# Altium schematic sheet 04 DI.SchDoc
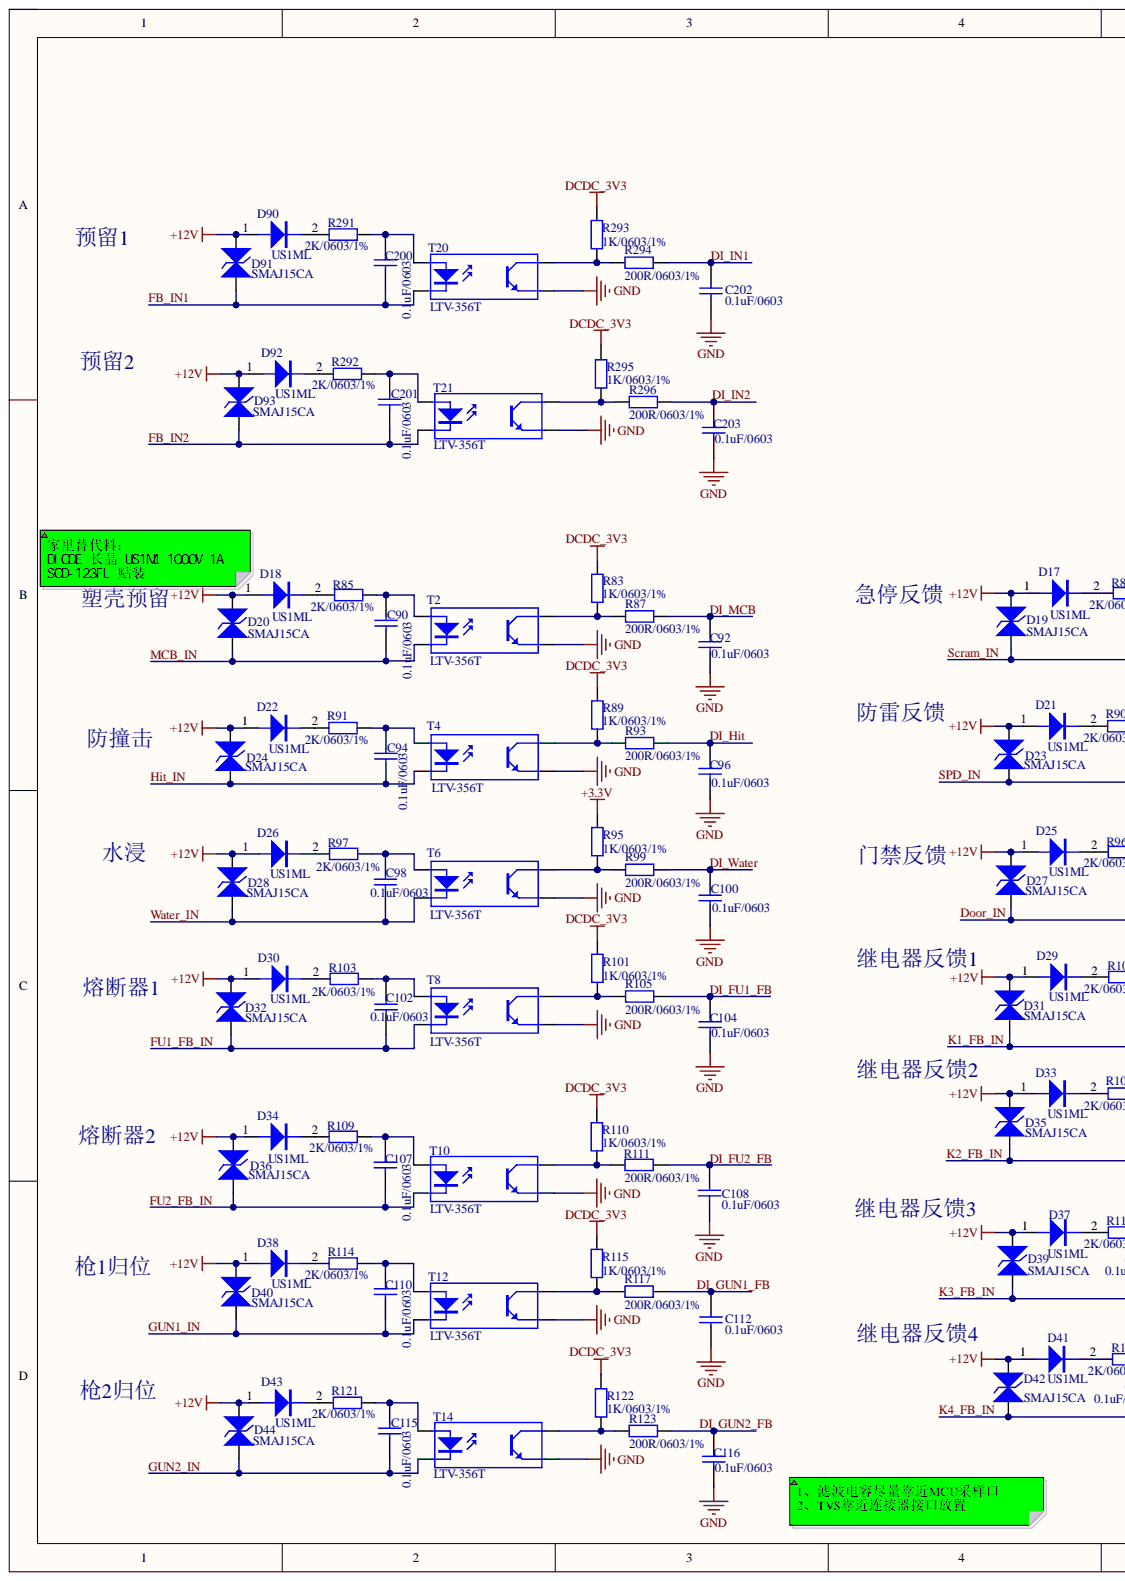
[diagram p0: 04 DI.SchDoc, left]
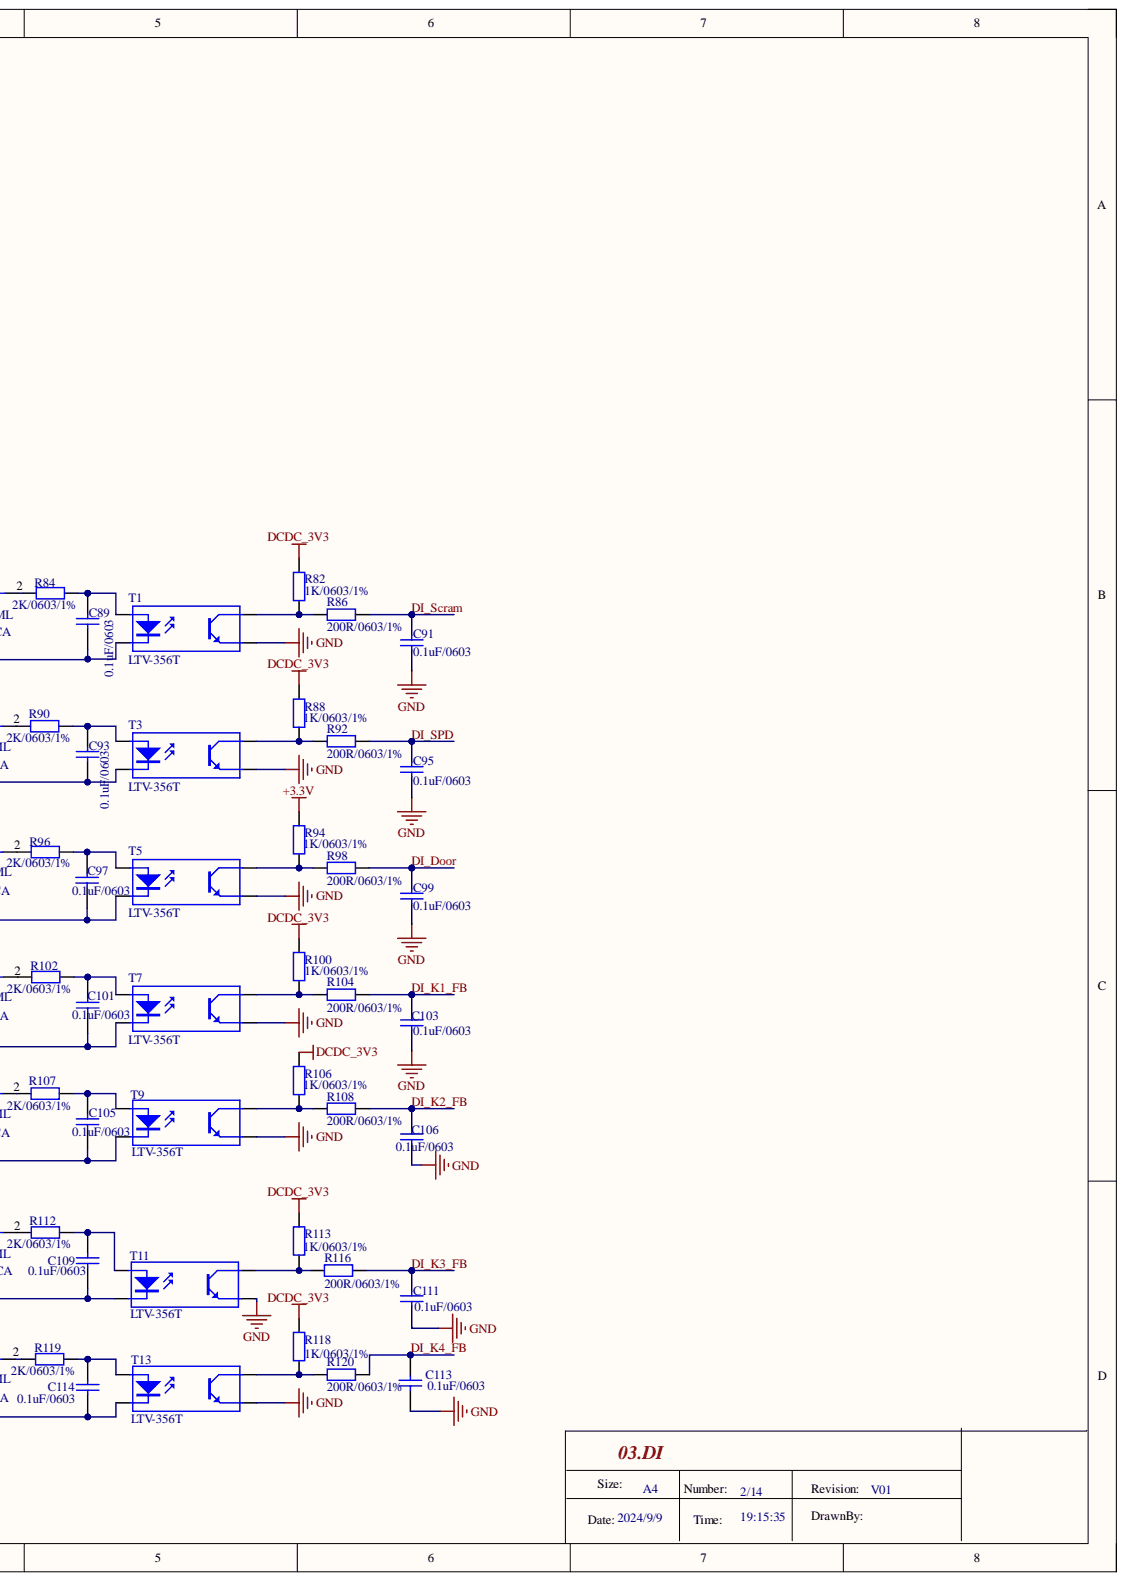
[diagram p1: 04 DI.SchDoc, right]

## Parts
C89  0.1uF/0603  [C0603]
C90  0.1uF/0603  [C0603]
C91  0.1uF/0603  [C0603]
C92  0.1uF/0603  [C0603]
C93  0.1uF/0603  [C0603]
C94  0.1uF/0603  [C0603]
C95  0.1uF/0603  [C0603]
C96  0.1uF/0603  [C0603]
C97  0.1uF/0603  [C0603]
C98  0.1uF/0603  [C0603]
C99  0.1uF/0603  [C0603]
C100  0.1uF/0603  [C0603]
C101  0.1uF/0603  [C0603]
C102  0.1uF/0603  [C0603]
C103  0.1uF/0603  [C0603]
C104  0.1uF/0603  [C0603]
C105  0.1uF/0603  [C0603]
C106  0.1uF/0603  [C0603]
C107  0.1uF/0603  [C0603]
C108  0.1uF/0603  [C0603]
C109  0.1uF/0603  [C0603]
C110  0.1uF/0603  [C0603]
C111  0.1uF/0603  [C0603]
C112  0.1uF/0603  [C0603]
C113  0.1uF/0603  [C0603]
C114  0.1uF/0603  [C0603]
C115  0.1uF/0603  [C0603]
C116  0.1uF/0603  [C0603]
C200  0.1uF/0603  [C0603]
C201  0.1uF/0603  [C0603]
C202  0.1uF/0603  [C0603]
C203  0.1uF/0603  [C0603]
D17  US1ML  [SOD123]
D18  US1ML  [SOD123]
D19  SMAJ15CA  [SMA]
D20  SMAJ15CA  [SMA]
D21  US1ML  [SOD123]
D22  US1ML  [SOD123]
D23  SMAJ15CA  [SMA]
D24  SMAJ15CA  [SMA]
D25  US1ML  [SOD123]
D26  US1ML  [SOD123]
D27  SMAJ15CA  [SMA]
D28  SMAJ15CA  [SMA]
D29  US1ML  [SOD123]
D30  US1ML  [SOD123]
D31  SMAJ15CA  [SMA]
D32  SMAJ15CA  [SMA]
D33  US1ML  [SOD123]
D34  US1ML  [SOD123]
D35  SMAJ15CA  [SMA]
D36  SMAJ15CA  [SMA]
D37  US1ML  [SOD123]
D38  US1ML  [SOD123]
D39  SMAJ15CA  [SMA]
D40  SMAJ15CA  [SMA]
D41  US1ML  [SOD123]
D42  SMAJ15CA  [SMA]
D43  US1ML  [SOD123]
D44  SMAJ15CA  [SMA]
D90  US1ML  [SOD123]
D91  SMAJ15CA  [SMA]
D92  US1ML  [SOD123]
D93  SMAJ15CA  [SMA]
R82  1K/0603/1%  [R0603]
R83  1K/0603/1%  [R0603]
R84  2K/0603/1%  [R0603]
R85  2K/0603/1%  [R0603]
R86  200R/0603/1%  [R0603]
R87  200R/0603/1%  [R0603]
R88  1K/0603/1%  [R0603]
R89  1K/0603/1%  [R0603]
R90  2K/0603/1%  [R0603]
R91  2K/0603/1%  [R0603]
R92  200R/0603/1%  [R0603]
R93  200R/0603/1%  [R0603]
R94  1K/0603/1%  [R0603]
R95  1K/0603/1%  [R0603]
R96  2K/0603/1%  [R0603]
R97  2K/0603/1%  [R0603]
R98  200R/0603/1%  [R0603]
R99  200R/0603/1%  [R0603]
R100  1K/0603/1%  [R0603]
R101  1K/0603/1%  [R0603]
R102  2K/0603/1%  [R0603]
R103  2K/0603/1%  [R0603]
R104  200R/0603/1%  [R0603]
R105  200R/0603/1%  [R0603]
R106  1K/0603/1%  [R0603]
R107  2K/0603/1%  [R0603]
R108  200R/0603/1%  [R0603]
R109  2K/0603/1%  [R0603]
R110  1K/0603/1%  [R0603]
R111  200R/0603/1%  [R0603]
R112  2K/0603/1%  [R0603]
R113  1K/0603/1%  [R0603]
R114  2K/0603/1%  [R0603]
R115  1K/0603/1%  [R0603]
R116  200R/0603/1%  [R0603]
R117  200R/0603/1%  [R0603]
R118  1K/0603/1%  [R0603]
R119  2K/0603/1%  [R0603]
R120  200R/0603/1%  [R0603]
R121  2K/0603/1%  [R0603]
R122  1K/0603/1%  [R0603]
R123  200R/0603/1%  [R0603]
R291  2K/0603/1%  [R0603]
R292  2K/0603/1%  [R0603]
R293  1K/0603/1%  [R0603]
R294  200R/0603/1%  [R0603]
R295  1K/0603/1%  [R0603]
R296  200R/0603/1%  [R0603]
T1  LTV-356T  [SOP-4]
T2  LTV-356T  [SOP-4]
T3  LTV-356T  [SOP-4]
T4  LTV-356T  [SOP-4]
T5  LTV-356T  [SOP-4]
T6  LTV-356T  [SOP-4]
T7  LTV-356T  [SOP-4]
T8  LTV-356T  [SOP-4]
T9  LTV-356T  [SOP-4]
T10  LTV-356T  [SOP-4]
T11  LTV-356T  [SOP-4]
T12  LTV-356T  [SOP-4]
T13  LTV-356T  [SOP-4]
T14  LTV-356T  [SOP-4]
T20  LTV-356T  [SOP-4]
T21  LTV-356T  [SOP-4]

## Nets
+3.3V: R94.2 R95.2
+12V: D17.1 D18.1 D19.2 D20.2 D21.1 D22.1 D23.2 D24.2 D25.1 D26.1 D27.2 D28.2 D29.1 D30.1 D31.2 D32.2 D33.1 D34.1 D35.2 D36.2 D37.1 D38.1 D39.2 D40.2 D41.1 D42.2 D43.1 D44.2 D90.1 D91.2 D92.1 D93.2
DCDC_3V3: R82.2 R83.2 R88.2 R89.2 R100.2 R101.2 R106.2 R110.2 R113.2 R115.2 R118.2 R122.2 R293.2 R295.2
GND: C91.1 C92.1 C95.1 C96.1 C99.1 C100.1 C103.1 C104.1 C106.1 C108.1 C111.1 C112.1 C113.1 C116.1 C202.1 C203.1 T1.3 T2.3 T3.3 T4.3 T5.3 T6.3 T7.3 T8.3 T9.3 T10.3 T11.3 T12.3 T13.3 T14.3 T20.3 T21.3
DI_Door: C99.2 R98.2
DI_FU1_FB: C104.2 R105.2
DI_FU2_FB: C108.2 R111.2
DI_GUN1_FB: C112.2 R117.2
DI_GUN2_FB: C116.2 R123.2
DI_Hit: C96.2 R93.2
DI_IN1: C202.2 R294.2
DI_IN2: C203.2 R296.2
DI_K1_FB: C103.2 R104.2
DI_K2_FB: C106.2 R108.2
DI_K3_FB: C111.2 R116.2
DI_K4_FB: C113.2 R120.2
DI_MCB: C92.2 R87.2
DI_Scram: C91.2 R86.2
DI_SPD: C95.2 R92.2
DI_Water: C100.2 R99.2
Door_IN: C97.1 D27.1 T5.2
FB_IN1: C200.1 D91.1 T20.2
FB_IN2: C201.1 D93.1 T21.2
FU1_FB_IN: C102.1 D32.1 T8.2
FU2_FB_IN: C107.1 D36.1 T10.2
GUN1_IN: C110.1 D40.1 T12.2
GUN2_IN: C115.1 D44.1 T14.2
Hit_IN: C94.1 D24.1 T4.2
K1_FB_IN: C101.1 D31.1 T7.2
K2_FB_IN: C105.1 D35.1 T9.2
K3_FB_IN: C109.1 D39.1 T11.2
K4_FB_IN: C114.1 D42.1 T13.2
MCB_IN: C90.1 D20.1 T2.2
Scram_IN: C89.1 D19.1 T1.2
SPD_IN: C93.1 D23.1 T3.2
Water_IN: C98.1 D28.1 T6.2
NetC89_2: C89.2 R84.2 T1.1
NetC90_2: C90.2 R85.2 T2.1
NetC93_2: C93.2 R90.2 T3.1
NetC94_2: C94.2 R91.2 T4.1
NetC97_2: C97.2 R96.2 T5.1
NetC98_2: C98.2 R97.2 T6.1
NetC101_2: C101.2 R102.2 T7.1
NetC102_2: C102.2 R103.2 T8.1
NetC105_2: C105.2 R107.2 T9.1
NetC107_2: C107.2 R109.2 T10.1
NetC109_2: C109.2 R112.2 T11.1
NetC110_2: C110.2 R114.2 T12.1
NetC114_2: C114.2 R119.2 T13.1
NetC115_2: C115.2 R121.2 T14.1
NetC200_2: C200.2 R291.2 T20.1
NetC201_2: C201.2 R292.2 T21.1
NetD17_2: D17.2 R84.1
NetD18_2: D18.2 R85.1
NetD21_2: D21.2 R90.1
NetD22_2: D22.2 R91.1
NetD25_2: D25.2 R96.1
NetD26_2: D26.2 R97.1
NetD29_2: D29.2 R102.1
NetD30_2: D30.2 R103.1
NetD33_2: D33.2 R107.1
NetD34_2: D34.2 R109.1
NetD37_2: D37.2 R112.1
NetD38_2: D38.2 R114.1
NetD41_2: D41.2 R119.1
NetD43_2: D43.2 R121.1
NetD90_2: D90.2 R291.1
NetD92_2: D92.2 R292.1
NetR82_1: R82.1 R86.1 T1.4
NetR83_1: R83.1 R87.1 T2.4
NetR88_1: R88.1 R92.1 T3.4
NetR89_1: R89.1 R93.1 T4.4
NetR94_1: R94.1 R98.1 T5.4
NetR95_1: R95.1 R99.1 T6.4
NetR100_1: R100.1 R104.1 T7.4
NetR101_1: R101.1 R105.1 T8.4
NetR106_1: R106.1 R108.1 T9.4
NetR110_1: R110.1 R111.1 T10.4
NetR113_1: R113.1 R116.1 T11.4
NetR115_1: R115.1 R117.1 T12.4
NetR118_1: R118.1 R120.1 T13.4
NetR122_1: R122.1 R123.1 T14.4
NetR293_1: R293.1 R294.1 T20.4
NetR295_1: R295.1 R296.1 T21.4

## Library data of the parts
C89-C116 C200-C203 = 0.1uF/50V/0603/X7R: 贴片电容,0.1uF-50V-X7R-0603-10%; 品牌: YAGEO; 型号规格: CC0603KRX7R9BB104; 焊接类型: SMT; Component Kind: Standard; ERP code: ELC.0258; Library Name: DH_Capacitance_Standard.SchLib
D17 D18 D21 D22 D25 D26 D29 D30 D33 D34 D37 D38 D41 D43 D90 D92 = SMT_1N4004: DIODE 长晶 US1ML 1000V 1A SOD-123FL 贴装; 品牌: 常州星海; Component Kind: Standard; Library Name: ZKM1V02.SCHLIB
D19 D20 D23 D24 D27 D28 D31 D32 D35 D36 D39 D40 D42 D44 D91 D93 = SJD12CA15L01: TVS 扬杰 SMAJ15CA 16.7V 400W SMA 贴装; 品牌: BrightKing; 型号规格: SJD12C15L01; 焊接类型: SMT; Component Kind: Standard; ERP Code: ELC.0125; Library Name: DH_Diode_Standard.SchLib
R82 R83 R88 R89 R94 R95 R100 R101 R106 R110 R113 R115 R118 R122 R293 R295 = 4.7K/0603/1%: 贴片电阻,4.7K-1/10W-0603-1%; 品牌: YAGEO; 型号规格: RC0603FR-074K7L; 焊接类型: SMT; Component Kind: Standard; ERP code: ELC.0272; Library Name: DH_Resistance_Standard.SchLib
R84 R85 R90 R91 R96 R97 R102 R103 R107 R109 R112 R114 R119 R121 R291 R292 = 2.2K/0603/1%: 贴片电阻,2.2K-1/10W-0603-1%; 品牌: YAGEO; 型号规格: RC0603FR-072K2L; 焊接类型: SMT; Component Kind: Standard; ERP Code: ELC.0386; Library Name: DH_Resistance_Standard.SchLib
R86 R87 R92 R93 R98 R99 R104 R105 R108 R111 R116 R117 R120 R123 R294 R296 = 200R/0603/1%: 贴片电阻,200R-1/10W-0603-1%; 品牌: YAGEO; 型号规格: RC0603FR-07200RL; 焊接类型: SMT; Component Kind: Standard; ERP Code: ELC.0407; Library Name: DH_Resistance_Standard.SchLib
T1-T14 T20 T21 = HCPL-181-00DE: 光耦; 品牌: Avago; 焊接类型: SMT; Component Kind: Standard; ERP code: ELC.0002; Library Name: DH_Chip_Standard.SchLib; 型号规格: HCPL-181-00DE
